# Altium schematic sheet [07] - BLE MODULE.SchDoc("[07] - BLE MODULE")
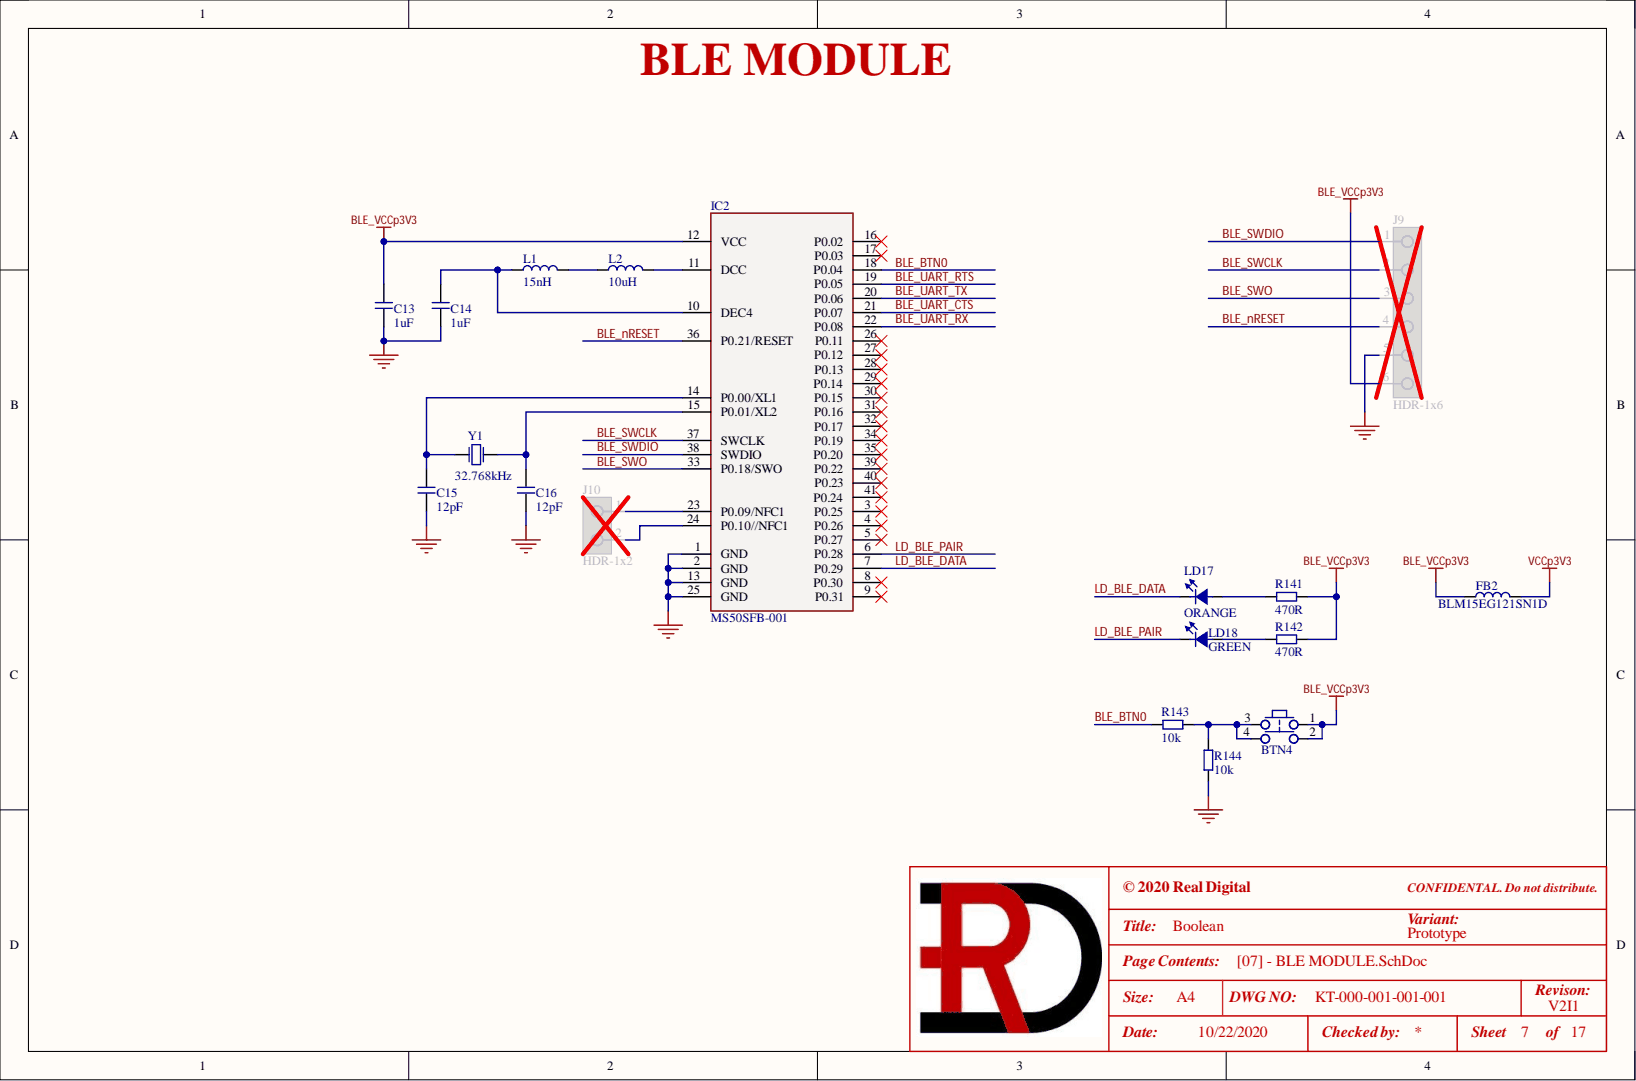
[diagram p0: [07] - BLE MODULE.SchDoc]

## Parts
BTN4  PTA-142  [PTA-142]  (value not drawn)
C13  1uF  [C0603]
C14  1uF  [C0603]
C15  12pF  [C0402]
C16  12pF  [C0402]
FB2  BLM15EG121SN1D  [BLM15]
IC2  MS50SFB-001  [MS50SFB-001]
J9  HDR-1x6  [HDR1X6 - OFF]
J10  HDR-1x2  [HDR1X2]
L1  15nH  [IND0402]
L2  10uH  [IND0603]
LD17  ORANGE  [LD0603_ORANGE]
LD18  GREEN  [LD0603_Green]
R141  470R  [R0402]
R142  470R  [R0402]
R143  10k  [R0402]
R144  10k  [R0402]
Y1  32.768kHz  [ECX-31B]

## Nets
BLE_VCCp3V3: BTN4.1 BTN4.2 C13.2 FB2.1 IC2.12(VCC) J9.6 R141.2 R142.2
GND: C13.1 C14.1 C15.1 C16.1 IC2.1(GND) IC2.2(GND) IC2.13(GND) IC2.25(GND) J9.5 R144.1
VCCp3V3: FB2.2
BLE_BTN0: IC2.18(P0.04) R143.2
BLE_nRESET: IC2.36(P0.21/RESET) J9.4
BLE_SWCLK: IC2.37(SWCLK) J9.2
BLE_SWDIO: IC2.38(SWDIO) J9.1
BLE_SWO: IC2.33(P0.18/SWO) J9.3
BLE_UART_CTS: IC2.21(P0.07)
BLE_UART_RTS: IC2.19(P0.05)
BLE_UART_RX: IC2.22(P0.08)
BLE_UART_TX: IC2.20(P0.06)
LD_BLE_DATA: IC2.7(P0.29) LD17.K
LD_BLE_PAIR: IC2.6(P0.28) LD18.K
NetBTN4_3: BTN4.3 BTN4.4 R143.1 R144.2
NetC14_2: C14.2 IC2.10(DEC4) L1.1
NetC15_2: C15.2 IC2.14(P0.00/XL1) Y1.1
NetC16_2: C16.2 IC2.15(P0.01/XL2) Y1.2
NetIC2_11: IC2.11(DCC) L2.2
NetIC2_23: IC2.23(P0.09/NFC1) J10.1
NetIC2_24: IC2.24(P0.10//NFC1) J10.2
NetL1_2: L1.2 L2.1
NetLD17_A: LD17.A R141.1
NetLD18_A: LD18.A R142.1

Not connected: IC2 3(P0.25) 4(P0.26) 5(P0.27) 8(P0.30) 9(P0.31) 16(P0.02) 17(P0.03) 26(P0.11) 27(P0.12) 28(P0.13) 29(P0.14) 30(P0.15) 31(P0.16) 32(P0.17) 34(P0.19) 35(P0.20) 39(P0.22) 40(P0.23) 41(P0.24)

## Library data of the parts
BTN4 = Tact Switch: SWITCH TACTILE SPST-NO, 4.50mm x 4.50mm; Manufacturer 1: Pacer Technology Co., Ltd; Manufacturer 2 Part Number: TSXH-2GL; .Creator: RV; C P/N: 153-0059; LoadStatus: Load; Category: Tactile Switches; Component Kind: Standard; Library Name: KT-RealDigital.IntLib; Manufacturer 1 Part Number: PTA-142
C13 C14 = GCJ188R71E105KA01D: CAP CER 1uF 25V X7R 0603; Manufacturer 1: Murata Electronics; .Checked_PCB: AC; .Creator: RV; C P/N: 111-0602; LoadStatus: Load; Tolerance: 10%; Category: Capacitors; Component Kind: Standard; Library Name: KT-RealDigital.IntLib; Manufacturer 1 Part Number: GCJ188R71E105KA01D; Temperature Coefficient: X7R; Voltage - Rated: 25V; .Checked_SCH: AC
C15 C16 = GCM1555C1H120FA16D: CAP CER 12pF 50V C0G/NP0 0402; Manufacturer 1: Murata Electronics; .Checked_PCB: AC; .Creator: RV; C P/N: RD-111-0005; LoadStatus: Load; Temperature Coefficient: C0G, NP0; Tolerance: 1%; Category: Capacitors; Component Kind: Standard; Library Name: KT-RealDigital.IntLib; Manufacturer 1 Part Number: GCM1555C1H120FA16D; Voltage - Rated: 50V; .Checked_SCH: AC
FB2 = BLM15EG121SN1D: FERRITE BEAD 120 OHM 0402 1LN; Manufacturer 1: Murata Electronics; .Checked_PCB: AC; .Creator: RV; C P/N: 131-0493; LoadStatus: Load; Category: Ferrite Beads; Component Kind: Standard; Library Name: KT-RealDigital.IntLib; Manufacturer 1 Part Number: BLM15EG121SN1D; .Checked_SCH: AC
IC2 = MS50SFB-001: BLE Module; Manufacturer 1: Minew; .Creator: RV; C P/N: RD-350-0001; LoadStatus: Load; Category: BLE Module; Component Kind: Standard; Library Name: KT-RealDigital.IntLib; Manufacturer 1 Part Number: MS50SFB-001
J9 = HDR-1x6: Header 2.54mm, 1x6; .Checked_PCB: AC; .Creator: RV; LoadStatus: Load; Category: Header; Component Kind: Standard; Library Name: KT-RealDigital.IntLib; .Checked_SCH: AC
J10 = HDR-1x2: Header 2.54mm, 1x2; Manufacturer 1: Centronic Precision Electronic; Manufacturer 1 Part Number: H6-80-02; .Checked_PCB: AC; .Creator: RV; C P/N: 163-0028; LoadStatus: Load; Category: Header; Component Kind: Standard; Library Name: KT-RealDigital.IntLib; .Checked_SCH: AC
L1 = BSCH0010050515NJCS: FIXED IND 15nH 300mA 320mOHM SMD; Manufacturer 1: Chilisin Electronics; .Checked_PCB: AC; .Creator: RV; C P/N: RD-131-0004; LoadStatus: Load; Category: Inductors; Component Kind: Standard; Library Name: KT-RealDigital.IntLib; Manufacturer 1 Part Number: BSCH0010050515NJCS; .Checked_SCH: AC
L2 = LBMF1608T100K: FIXED IND 10uH 80mA 360 mOhm SMD; Manufacturer 1: Taiyo Yuden; .Checked_PCB: AC; .Creator: RV; C P/N: RD-131-0003; LoadStatus: Load; Category: Inductors; Component Kind: Standard; Library Name: KT-RealDigital.IntLib; Manufacturer 1 Part Number: LBMF1608T100K; .Checked_SCH: AC
LD17 = LED_ORANGE: LED ORANGE 0603; Manufacturer 1: Victory Electronics; Manufacturer 1 Part Number: VS 28A8M; .Checked_PCB: AC; .Creator: RV; C P/N: 121-1275; LoadStatus: Load; Category: Led; Component Kind: Standard; Library Name: KT-RealDigital.IntLib; .Checked_SCH: AC
LD18 = LED_GREEN: LED GREEN 0603; Manufacturer 1: Everlight Electronics CO., LTD; Manufacturer 1 Part Number: 19-21SYGC/S530-E4/TR8; .Checked_PCB: AC; .Creator: RV; C P/N: 121-0006; LoadStatus: Load; Category: Led; Component Kind: Standard; Library Name: KT-RealDigital.IntLib; .Checked_SCH: AC
R141 R142 = RC0402JR-07470RL: RES SMD 470 OHM 5% 1/16W 0402; Manufacturer 1: Yageo; .Checked_PCB: AC; .Creator: RV; C P/N: 101-0052; LoadStatus: Load; Category: Resistors; Component Kind: Standard; Library Name: KT-RealDigital.IntLib; Manufacturer 1 Part Number: RC0402JR-07470RL; Tolerance: 5%; .Checked_SCH: AC
R143 R144 = RC0402JR-0710KL: RES SMD 10K OHM 5% 1/16W 0402; Manufacturer 1: Yageo; .Checked_PCB: AC; .Creator: RV; C P/N: 101-0047; LoadStatus: Load; Category: Resistors; Component Kind: Standard; Library Name: KT-RealDigital.IntLib; Manufacturer 1 Part Number: RC0402JR-0710KL; Tolerance: 5%; .Checked_SCH: AC
Y1 = ECS-.327-9-34B-TR: CRYSTAL 32.7680kHz 9pF SMD; Manufacturer 1: ECS Inc.; .Checked_PCB: AC; .Creator: RV; C P/N: RD-126-0001; LoadStatus: Load; Category: Crystals; Component Kind: Standard; Library Name: KT-RealDigital.IntLib; Manufacturer 1 Part Number: ECS-.327-9-34B-TR; .Checked_SCH: AC
